# Altium schematic sheet merci_main.SchDoc(merci_main)
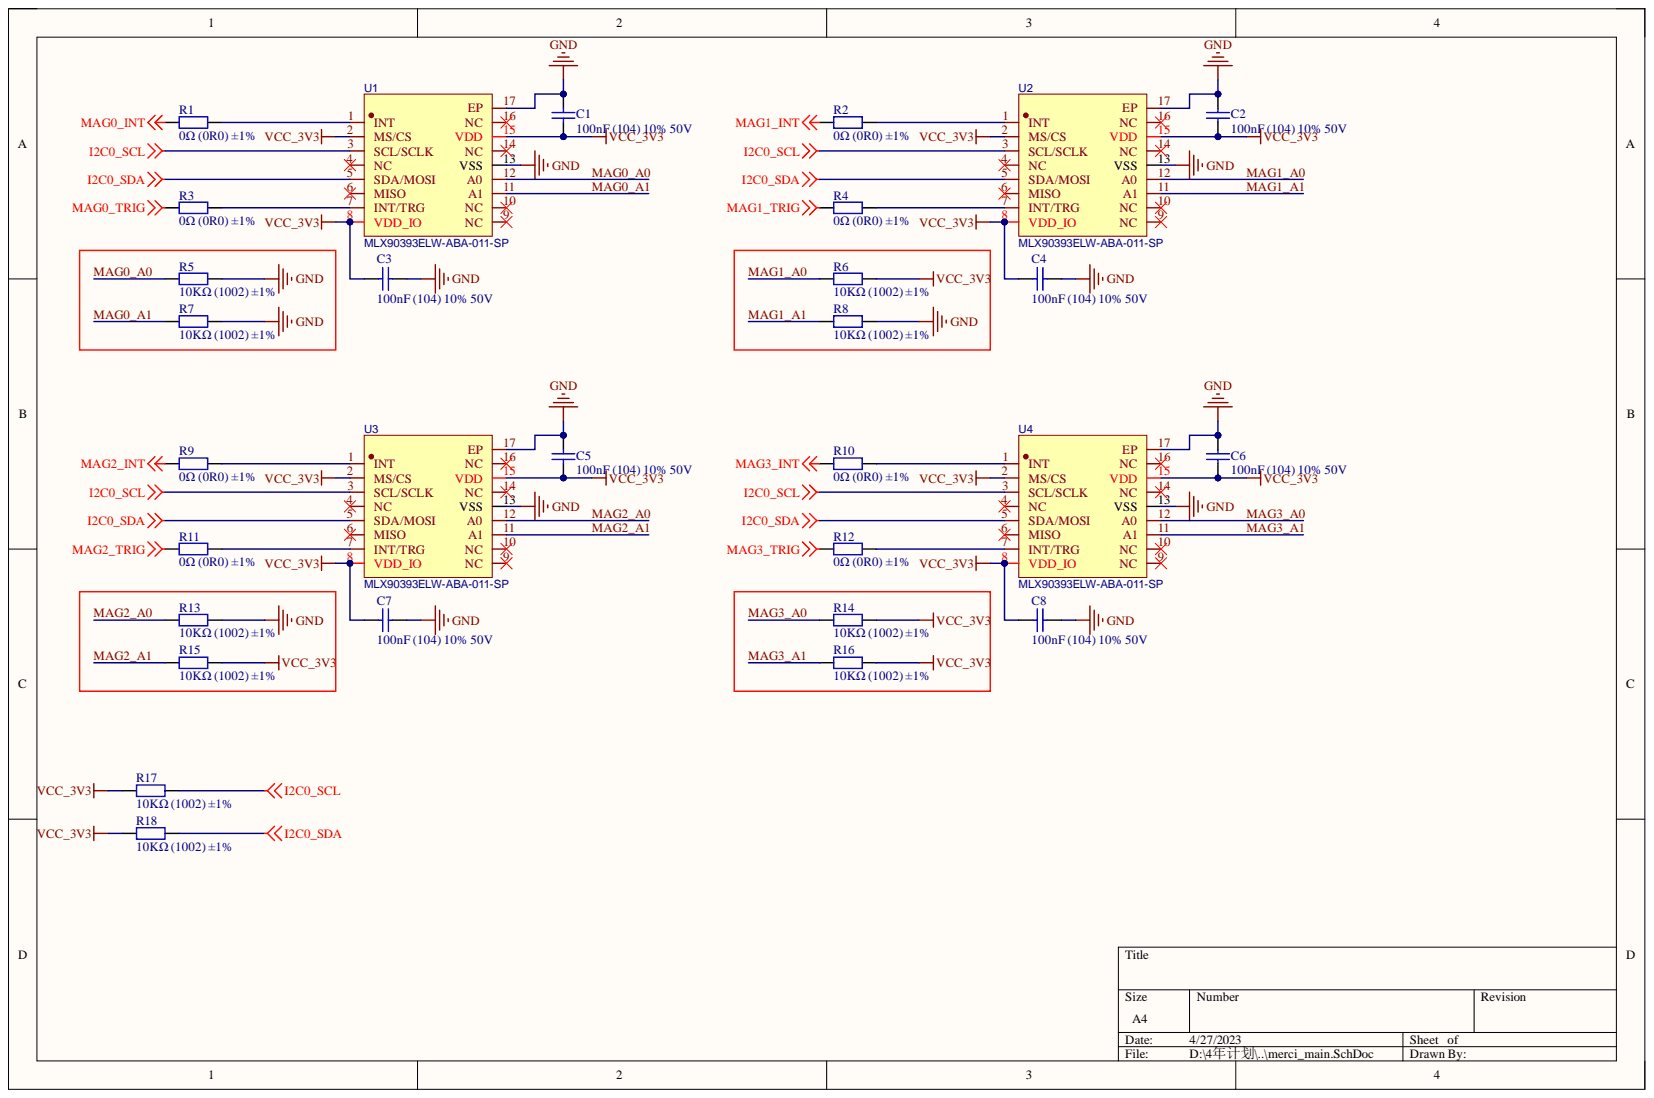
[diagram p0: merci_main.SchDoc]

## Parts
C1  100nF (104) 10% 50V  [LC-0603_C]
C2  100nF (104) 10% 50V  [LC-0603_C]
C3  100nF (104) 10% 50V  [LC-0603_C]
C4  100nF (104) 10% 50V  [LC-0603_C]
C5  100nF (104) 10% 50V  [LC-0603_C]
C6  100nF (104) 10% 50V  [LC-0603_C]
C7  100nF (104) 10% 50V  [LC-0603_C]
C8  100nF (104) 10% 50V  [LC-0603_C]
R1  0Ω (0R0) ±1%  [LC-0603_R]
R2  0Ω (0R0) ±1%  [LC-0603_R]
R3  0Ω (0R0) ±1%  [LC-0603_R]
R4  0Ω (0R0) ±1%  [LC-0603_R]
R5  10KΩ (1002) ±1%  [LC-0603_R]
R6  10KΩ (1002) ±1%  [LC-0603_R]
R7  10KΩ (1002) ±1%  [LC-0603_R]
R8  10KΩ (1002) ±1%  [LC-0603_R]
R9  0Ω (0R0) ±1%  [LC-0603_R]
R10  0Ω (0R0) ±1%  [LC-0603_R]
R11  0Ω (0R0) ±1%  [LC-0603_R]
R12  0Ω (0R0) ±1%  [LC-0603_R]
R13  10KΩ (1002) ±1%  [LC-0603_R]
R14  10KΩ (1002) ±1%  [LC-0603_R]
R15  10KΩ (1002) ±1%  [LC-0603_R]
R16  10KΩ (1002) ±1%  [LC-0603_R]
R17  10KΩ (1002) ±1%  [LC-0603_R]
R18  10KΩ (1002) ±1%  [LC-0603_R]
U1  MLX90393ELW-ABA-011-SP  [QFN-16_L3.0-W3.0-P0.50-TR-EP1.7]
U2  MLX90393ELW-ABA-011-SP  [QFN-16_L3.0-W3.0-P0.50-TR-EP1.7]
U3  MLX90393ELW-ABA-011-SP  [QFN-16_L3.0-W3.0-P0.50-TR-EP1.7]
U4  MLX90393ELW-ABA-011-SP  [QFN-16_L3.0-W3.0-P0.50-TR-EP1.7]

## Nets
GND: C1.2 C2.2 C3.1 C4.1 C5.2 C6.2 C7.1 C8.1 R5.1 R7.1 R8.1 R13.1 U1.13(VSS) U1.17(EP) U2.13(VSS) U2.17(EP) U3.13(VSS) U3.17(EP) U4.13(VSS) U4.17(EP)
I2C0_SCL: R17.2 U1.3(SCL/SCLK) U2.3(SCL/SCLK) U3.3(SCL/SCLK) U4.3(SCL/SCLK)
I2C0_SDA: R18.1 U1.5(SDA/MOSI) U2.5(SDA/MOSI) U3.5(SDA/MOSI) U4.5(SDA/MOSI)
MAG0_INT: R1.1
MAG0_TRIG: R3.1
MAG1_INT: R2.1
MAG1_TRIG: R4.1
MAG2_INT: R9.1
MAG2_TRIG: R11.1
MAG3_INT: R10.1
MAG3_TRIG: R12.1
VCC_3V3: C1.1 C2.1 C3.2 C4.2 C5.1 C6.1 C7.2 C8.2 R6.1 R14.1 R15.1 R16.1 R17.1 R18.2 U1.2(MS/CS) U1.8(VDD_IO) U1.15(VDD) U2.2(MS/CS) U2.8(VDD_IO) U2.15(VDD) U3.2(MS/CS) U3.8(VDD_IO) U3.15(VDD) U4.2(MS/CS) U4.8(VDD_IO) U4.15(VDD)
MAG0_A0: R5.2 U1.12(A0)
MAG0_A1: R7.2 U1.11(A1)
MAG1_A0: R6.2 U2.12(A0)
MAG1_A1: R8.2 U2.11(A1)
MAG2_A0: R13.2 U3.12(A0)
MAG2_A1: R15.2 U3.11(A1)
MAG3_A0: R14.2 U4.12(A0)
MAG3_A1: R16.2 U4.11(A1)
NetR1_2: R1.2 U1.1(INT)
NetR2_2: R2.2 U2.1(INT)
NetR3_2: R3.2 U1.7(INT/TRG)
NetR4_2: R4.2 U2.7(INT/TRG)
NetR9_2: R9.2 U3.1(INT)
NetR10_2: R10.2 U4.1(INT)
NetR11_2: R11.2 U3.7(INT/TRG)
NetR12_2: R12.2 U4.7(INT/TRG)

Not connected: U1 4(NC) 6(MISO) 9(NC) 10(NC) 14(NC) 16(NC); U2 4(NC) 6(MISO) 9(NC) 10(NC) 14(NC) 16(NC); U3 4(NC) 6(MISO) 9(NC) 10(NC) 14(NC) 16(NC); U4 4(NC) 6(MISO) 9(NC) 10(NC) 14(NC) 16(NC)

## Library data of the parts
C1-C8 = 0603 100nF (104) 10% 50V: 贴片电容; Component Kind: Standard; ComponentLink1Description: 供应商链接; ComponentLink1URL: http://www.szlcsc.com/product/details_15331.html; Library Name: Miscellaneous Devices LC.IntLib; Package: 0603; Supplier: LC; SuppliersPartNumber: C14663
R1-R4 R9-R12 = 0603 0Ω (0R0) 1%: 贴片电阻; Component Kind: Standard; ComponentLink1Description: 供应商链接; ComponentLink1URL: http://www.szlcsc.com/product/details_21903.html; Library Name: Miscellaneous Devices LC.IntLib; Package: 0603; Supplier: LC; SuppliersPartNumber: C21189
R5-R8 R13-R18 = 0603 10KΩ (1002) 1%: 贴片电阻; Component Kind: Standard; ComponentLink1Description: 供应商链接; ComponentLink1URL: http://www.szlcsc.com/product/details_26547.html; Library Name: Miscellaneous Devices LC.IntLib; Package: 0603; Supplier: LC; SuppliersPartNumber: C25804
U1-U4 = MLX90393ELW-ABA-011-SP: BOM_Manufacturer: Melexis(比利时迈来芯); Contributor: LCSC; BOM_JLCPCB Part Class: Extended Part; BOM_Manufacturer Part: MLX90393ELW-ABA-011-SP; BOM_Supplier: LCSC; BOM_Supplier Part: C1526586; Component Kind: Standard; spicePre: U; spiceSymbolName: MLX90393ELW-ABA-011-SP; Library Name: merci.SchLib
